# Altium schematic sheet USB-C list.SchDoc
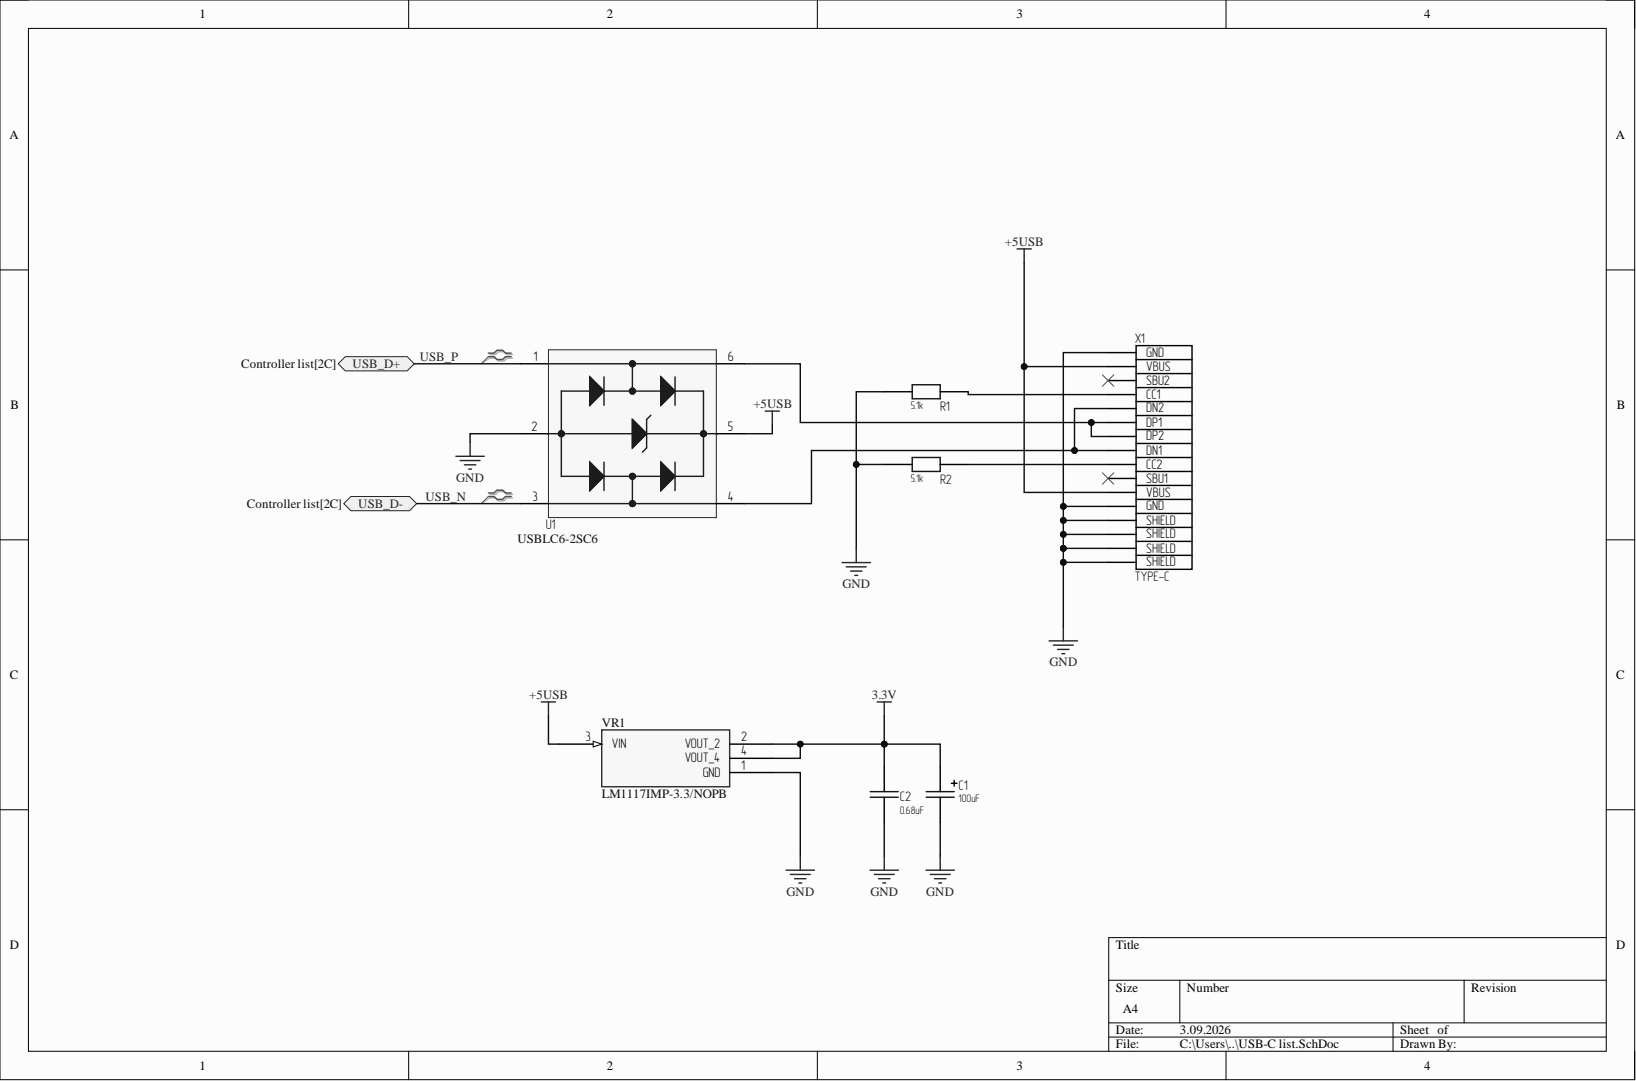
[diagram p0: USB-C list.SchDoc]

## Parts
C1  100uF  [TANTAL_TYPE_D]
C2  0.68uF  [C_0603]
R1  5.1k  [R_0603]
R2  5.1k  [R_0603]
U1  USBLC6-2SC6  [SOT95P280X145-6N]
VR1  LM1117IMP-3.3/NOPB  [VREG_LM1117IMP-3.3/NOPB]
X1  TYPE-C  [HRO_TYPE-C-31-M-12]

## Ports
USB_D+, USB_D-

## Nets
3.3V: C1.1 C2.2 VR1.2(VOUT_2) VR1.4(VOUT_4)
+5USB: U1.5 VR1.3(VIN) X1.A4B9(VBUS) X1.B4A9(VBUS)
GND: C1.2 C2.1 R1.1 R2.1 U1.2 VR1.1(GND) X1.A1B12(GND) X1.B1A12(GND) X1.S1(SHIELD) X1.S2(SHIELD) X1.S3(SHIELD) X1.S4(SHIELD)
USB_N: U1.3
USB_P: U1.1
NetR1_2: R1.2 X1.A5(CC1)
NetR2_2: R2.2 X1.B5(CC2)
NetU1_4: U1.4 X1.A7(DN1) X1.B7(DN2)
NetU1_6: U1.6 X1.A6(DP1) X1.B6(DP2)

Not connected: X1 A8(SBU1) B8(SBU2)

## Library data of the parts
C1 = TANTAL_CAP: Tantal Capacitor; Part: T491X476K035AT; Part number: T491D107M010AT; Alternative Part: -; Component Kind: Standard; Library Name: capacitors.schlib; Value: 100uF
C2 = C0603: Capacitor; Part number: CC0603KRX7R7BB684; Component Kind: Standard; Library Name: capacitors.schlib; Value: 0.68uF
R1 R2 = R0603: Resistor; Part number: RC0603FR-075K1L; Component Kind: Standard; Library Name: resistors.schlib; Value: 5.1k
U1 = USBLC6-2SC6: 17V Clamp 5A (8/20Âµs) Ipp Tvs Diode Surface Mount SOT-23-6; MF: STMicroelectronics; Availability: In Stock; Check_prices: https://www.snapeda.com/parts/USBLC6-2SC6/STMicroelectronics/view-part/?ref=eda; Component Kind: Standard; Library Name: diode.schlib; MP: USBLC6-2SC6; Package: SOT-23-6 STMicroelectronics; Part: USBLC6-2SC6; Part number: USBLC6-2SC6; Price: None; Purchase-URL: https://pricing.snapeda.com/search/part/USBLC6-2SC6/?ref=eda; SnapEDA_Link: https://www.snapeda.com/parts/USBLC6-2SC6/STMicroelectronics/view-part/?ref=snap
VR1 = LM1117IMP-3.3/NOPB: 800-mA 15-V linear voltage regulator; MF: Texas Instruments; Check_prices: https://www.snapeda.com/parts/LM1117IMP-3.3/NOPB/Texas+Instruments/view-part/?ref=eda; Component Kind: Standard; Library Name: LM1117IMP-3.3_NOPB.SchLib; MP: LM1117IMP-3.3/NOPB; Package: SOT-223-4 Texas Instruments; Part number: LM1117IMP-3.3; SnapEDA_Link: https://www.snapeda.com/parts/LM1117IMP-3.3/NOPB/Texas+Instruments/view-part/?ref=snap
X1 = TYPE-C-31-M-12: USB Connectors 24 Receptacle 1 8.94*7.3mm RoHS; Component Kind: Standard; Part: TYPE-C-31-M-12; Part number: TYPE-C-31-M-12; Library Name: connectors_socets.schlib
